# Altium schematic sheet VFD_Clock.SchDoc
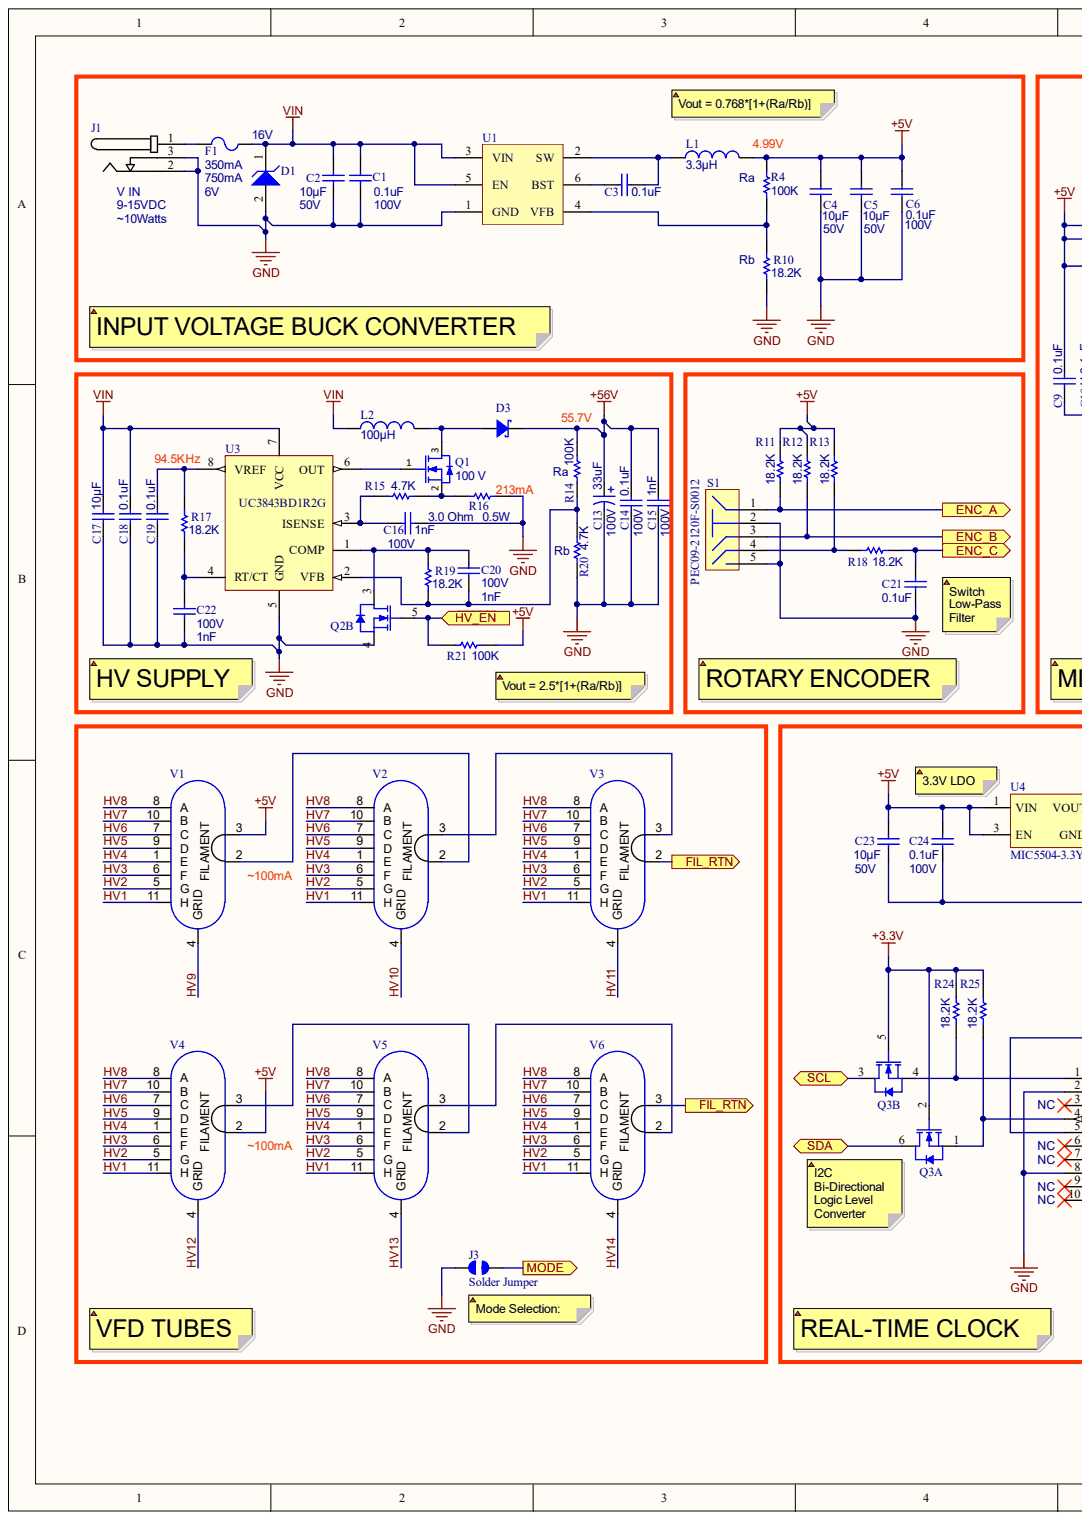
[diagram p0: VFD_Clock.SchDoc, left]
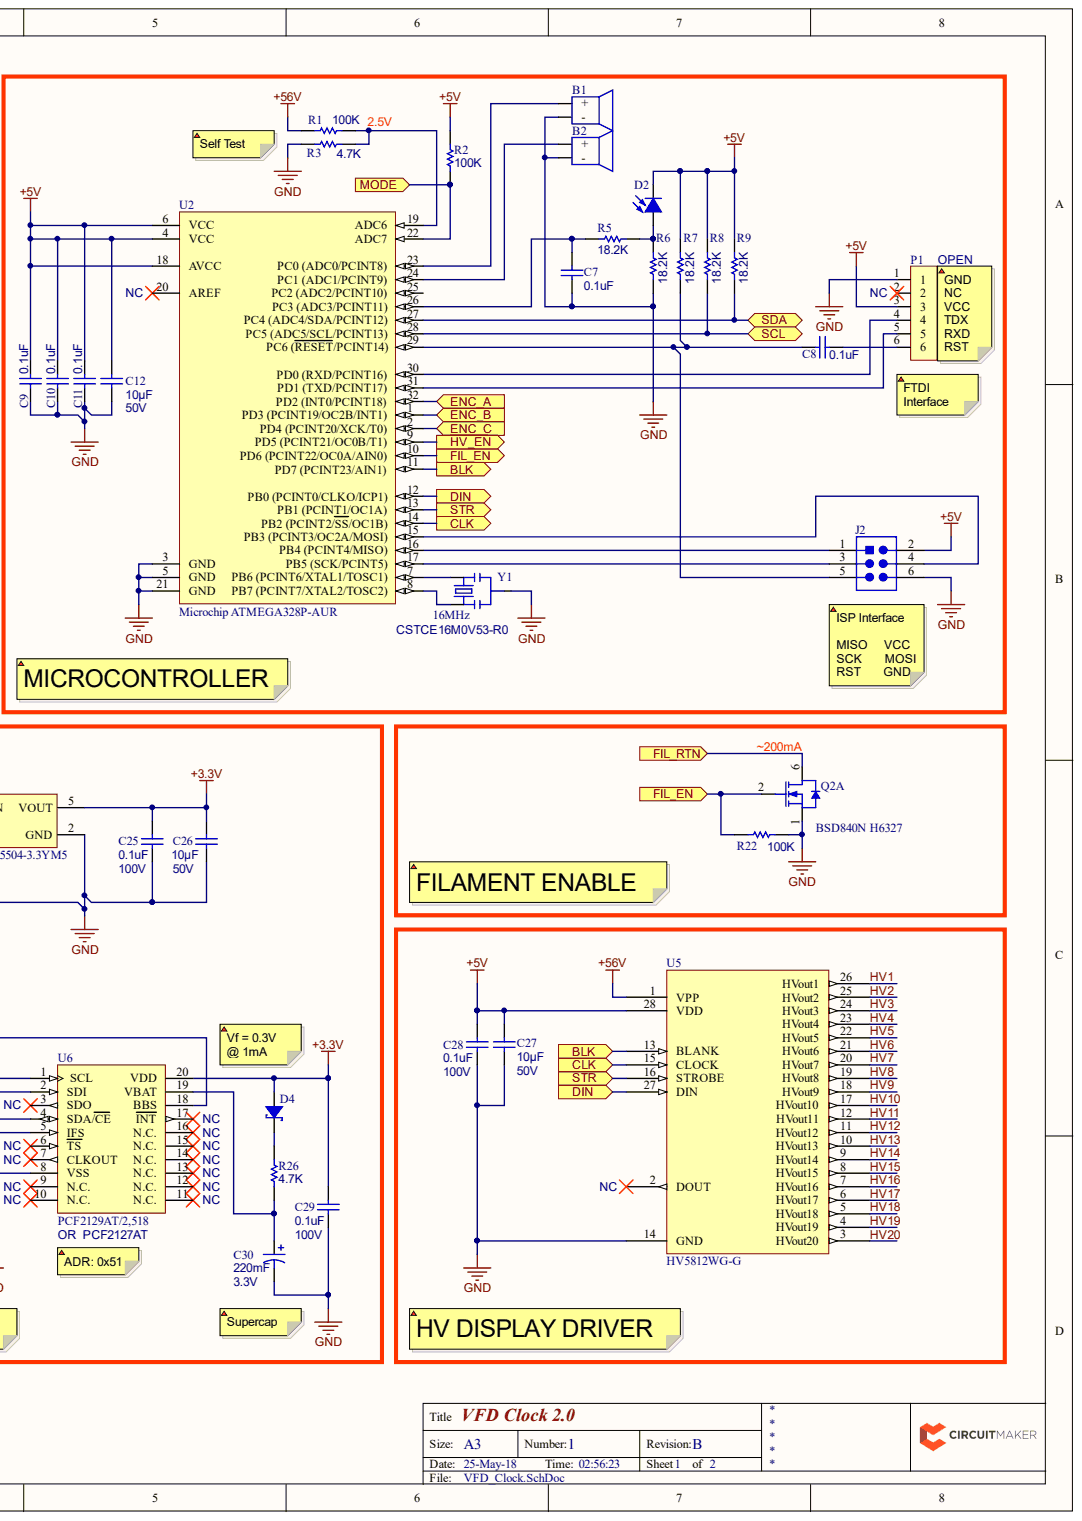
[diagram p1: VFD_Clock.SchDoc, right]

## Parts
B1  PUI Audio AT-1224-TWT-5V-2-R  [BUZZER-12MM-NS]  (value not drawn)
B2  PUI Audio AT-1224-TWT-5V-2-R  [BUZZER-12MM-NS]  (value not drawn)
C1  Capacitance: 0.1uF  Voltage Rating (DC): 100V  [PCB-3l8viaoeo84t9gm70k7i-1]  (hidden comment: Samsung CL21B104KCFNNNE)
C2  Capacitance: 10µF  Voltage Rating (DC): 50V  [PCB-sxvxwfnl72ysl1kmtzgv-1]  (hidden comment: Samsung CL31A106MBHNNNE)
C3  Capacitance: 0.1uF  [PCB-3l8viaoeo84t9gm70k7i-1]  (hidden comment: Samsung CL21B104KCFNNNE)
C4  Capacitance: 10µF  Voltage Rating (DC): 50V  [PCB-sxvxwfnl72ysl1kmtzgv-1]  (hidden comment: Samsung CL31A106MBHNNNE)
C5  Capacitance: 10µF  Voltage Rating (DC): 50V  [PCB-sxvxwfnl72ysl1kmtzgv-1]  (hidden comment: Samsung CL31A106MBHNNNE)
C6  Capacitance: 0.1uF  Voltage Rating (DC): 100V  [PCB-3l8viaoeo84t9gm70k7i-1]  (hidden comment: Samsung CL21B104KCFNNNE)
C7  Capacitance: 0.1uF  [PCB-3l8viaoeo84t9gm70k7i-1]  (hidden comment: Samsung CL21B104KCFNNNE)
C8  Capacitance: 0.1uF  [PCB-3l8viaoeo84t9gm70k7i-1]  (hidden comment: Samsung CL21B104KCFNNNE)
C9  Capacitance: 0.1uF  [PCB-3l8viaoeo84t9gm70k7i-1]  (hidden comment: Samsung CL21B104KCFNNNE)
C10  Capacitance: 0.1uF  [PCB-3l8viaoeo84t9gm70k7i-1]  (hidden comment: Samsung CL21B104KCFNNNE)
C11  Capacitance: 0.1uF  [PCB-3l8viaoeo84t9gm70k7i-1]  (hidden comment: Samsung CL21B104KCFNNNE)
C12  Capacitance: 10µF  Voltage Rating (DC): 50V  [PCB-sxvxwfnl72ysl1kmtzgv-1]  (hidden comment: Samsung CL31A106MBHNNNE)
C13  Capacitance: 33uF  Voltage Rating (DC): 100V  [PCB-u5adhgcz10k2otq2s848-1]  (hidden comment: Nichicon UCD2A330MNL1GS)
C14  Capacitance: 0.1uF  Voltage Rating (DC): 100V  [PCB-3l8viaoeo84t9gm70k7i-1]  (hidden comment: Samsung CL21B104KCFNNNE)
C15  Capacitance: 1nF  Voltage Rating (DC): 100V  [CAPC1608X09M]  (hidden comment: Murata GRM1885C2A102JA01D)
C16  Capacitance: 1nF  Voltage Rating (DC): 100V  [CAPC1608X09M]  (hidden comment: Murata GRM1885C2A102JA01D)
C17  Capacitance: 10µF  [PCB-sxvxwfnl72ysl1kmtzgv-1]  (hidden comment: Samsung CL31A106MBHNNNE)
C18  Capacitance: 0.1uF  [PCB-3l8viaoeo84t9gm70k7i-1]  (hidden comment: Samsung CL21B104KCFNNNE)
C19  Capacitance: 0.1uF  [PCB-3l8viaoeo84t9gm70k7i-1]  (hidden comment: Samsung CL21B104KCFNNNE)
C20  Capacitance: 1nF  Voltage Rating (DC): 100V  [CAPC1608X09M]  (hidden comment: Murata GRM1885C2A102JA01D)
C21  Capacitance: 0.1uF  [PCB-3l8viaoeo84t9gm70k7i-1]  (hidden comment: Samsung CL21B104KCFNNNE)
C22  Capacitance: 1nF  Voltage Rating (DC): 100V  [CAPC1608X09M]  (hidden comment: Murata GRM1885C2A102JA01D)
C23  Capacitance: 10µF  Voltage Rating (DC): 50V  [PCB-sxvxwfnl72ysl1kmtzgv-1]  (hidden comment: Samsung CL31A106MBHNNNE)
C24  Capacitance: 0.1uF  Voltage Rating (DC): 100V  [PCB-3l8viaoeo84t9gm70k7i-1]  (hidden comment: Samsung CL21B104KCFNNNE)
C25  Capacitance: 0.1uF  Voltage Rating (DC): 100V  [PCB-3l8viaoeo84t9gm70k7i-1]  (hidden comment: Samsung CL21B104KCFNNNE)
C26  Capacitance: 10µF  Voltage Rating (DC): 50V  [PCB-sxvxwfnl72ysl1kmtzgv-1]  (hidden comment: Samsung CL31A106MBHNNNE)
C27  Capacitance: 10µF  Voltage Rating (DC): 50V  [PCB-sxvxwfnl72ysl1kmtzgv-1]  (hidden comment: Samsung CL31A106MBHNNNE)
C28  Capacitance: 0.1uF  Voltage Rating (DC): 100V  [PCB-3l8viaoeo84t9gm70k7i-1]  (hidden comment: Samsung CL21B104KCFNNNE)
C29  Capacitance: 0.1uF  Voltage Rating (DC): 100V  [PCB-3l8viaoeo84t9gm70k7i-1]  (hidden comment: Samsung CL21B104KCFNNNE)
C30  Capacitance: 220mF  Voltage Rating (DC): 3.3V  [PCB-ed5g5zejftuwohhu6h14-1]  (hidden comment: Elna DSK-3R3H224U-HL)
D1  Voltage Rating (DC): 16V  [ONSC-SMB-2-403A-03_H_V]  (hidden comment: SMBJ5353B-TP)
D2  Vishay TEPT4400  [PCB-2bwclpntb2i50558vo9u-1]  (value not drawn)
D3  MCC SL110PL-TP  [PCB-zwpadio2r1s9y7nyy7p1-1]  (value not drawn)
D4  MCC SL110PL-TP  [PCB-zwpadio2r1s9y7nyy7p1-1]  (value not drawn)
F1  Current Hold: 350mA  [PCB-4097432-1]  (hidden comment: Bel Fuse 0ZCK0035FF2G)
J1  CUI PJ-102AH  [PCB-nca7odsozp801pu3ngpk-1]  (value not drawn)
J2  Harwin M20-8760342  [TAG-CONNECT]  (value not drawn)
J3  Solder Jumper  [PCB-jf5k9cyfk2c32myyyjc8-1]
L1  Inductance: 3.3µH  [PCB-nsta6in45csbfdu3v3kz-1]  (hidden comment: Bourns SRN6045-3R3Y)
L2  Inductance: 100µH  [PCB-nsta6in45csbfdu3v3kz-1]  (hidden comment: Bourns SRN6045TA-101M)
P1  Würth Elektronik 61300611121  [PCB-inh0upq8uddmt7lk8pts-1]  (value not drawn)
Q1  Drain to Source Voltage (Vds): 100 V  [ONSC-SOT-23-3-318-08_V]  (hidden comment: International Rectifier IRLML0100TRPBF)
Q2  BSD840N H6327  [PCB-o0ftgzu0ah68hvx9yn6p-1]  (parts A,B)
Q3  Infineon BSD840N H6327  [PCB-o0ftgzu0ah68hvx9yn6p-1]  (value not drawn)  (parts A,B)
R1  Resistance: 100K  [PCB-jf5k9cyfk2c32myyyjc8-1]  (hidden comment: Yageo RC0603FR-07100KL)
R2  Resistance: 100K  [PCB-jf5k9cyfk2c32myyyjc8-1]  (hidden comment: Yageo RC0603FR-07100KL)
R3  Resistance: 4.7K  [PCB-jf5k9cyfk2c32myyyjc8-1]  (hidden comment: Yageo RC0603FR-074K7L)
R4  Resistance: 100K  [PCB-jf5k9cyfk2c32myyyjc8-1]  (hidden comment: Yageo RC0603FR-07100KL)
R5  Resistance: 18.2K  [PCB-jf5k9cyfk2c32myyyjc8-1]  (hidden comment: Yageo RC0603FR-0718K2L)
R6  Resistance: 18.2K  [PCB-jf5k9cyfk2c32myyyjc8-1]  (hidden comment: Yageo RC0603FR-0718K2L)
R7  Resistance: 18.2K  [PCB-jf5k9cyfk2c32myyyjc8-1]  (hidden comment: Yageo RC0603FR-0718K2L)
R8  Resistance: 18.2K  [PCB-jf5k9cyfk2c32myyyjc8-1]  (hidden comment: Yageo RC0603FR-0718K2L)
R9  Resistance: 18.2K  [PCB-jf5k9cyfk2c32myyyjc8-1]  (hidden comment: Yageo RC0603FR-0718K2L)
R10  Resistance: 18.2K  [PCB-jf5k9cyfk2c32myyyjc8-1]  (hidden comment: Yageo RC0603FR-0718K2L)
R11  Resistance: 18.2K  [PCB-jf5k9cyfk2c32myyyjc8-1]  (hidden comment: Yageo RC0603FR-0718K2L)
R12  Resistance: 18.2K  [PCB-jf5k9cyfk2c32myyyjc8-1]  (hidden comment: Yageo RC0603FR-0718K2L)
R13  Resistance: 18.2K  [PCB-jf5k9cyfk2c32myyyjc8-1]  (hidden comment: Yageo RC0603FR-0718K2L)
R14  Resistance: 100K  [PCB-jf5k9cyfk2c32myyyjc8-1]  (hidden comment: Yageo RC0603FR-07100KL)
R15  Resistance: 4.7K  [PCB-jf5k9cyfk2c32myyyjc8-1]  (hidden comment: Yageo RC0603FR-074K7L)
R16  Power Rating: 0.5W  [RESC3216X70X50NL20T25]  (hidden comment: RC0603FR-074K7L)
R17  Resistance: 18.2K  [PCB-jf5k9cyfk2c32myyyjc8-1]  (hidden comment: Yageo RC0603FR-0718K2L)
R18  Resistance: 18.2K  [PCB-jf5k9cyfk2c32myyyjc8-1]  (hidden comment: Yageo RC0603FR-0718K2L)
R19  Resistance: 18.2K  [PCB-jf5k9cyfk2c32myyyjc8-1]  (hidden comment: Yageo RC0603FR-0718K2L)
R20  Resistance: 4.7K  [PCB-jf5k9cyfk2c32myyyjc8-1]  (hidden comment: Yageo RC0603FR-074K7L)
R21  Resistance: 100K  [PCB-jf5k9cyfk2c32myyyjc8-1]  (hidden comment: Yageo RC0603FR-07100KL)
R22  Resistance: 100K  [PCB-jf5k9cyfk2c32myyyjc8-1]  (hidden comment: Yageo RC0603FR-07100KL)
R24  Resistance: 18.2K  [PCB-jf5k9cyfk2c32myyyjc8-1]  (hidden comment: Yageo RC0603FR-0718K2L)
R25  Resistance: 18.2K  [PCB-jf5k9cyfk2c32myyyjc8-1]  (hidden comment: Yageo RC0603FR-0718K2L)
R26  Resistance: 4.7K  [PCB-jf5k9cyfk2c32myyyjc8-1]  (hidden comment: Yageo RC0603FR-074K7L)
S1  PEC09-2120F-S0012  [PCB-q6oao8b4bcypfm376829-1]
U1  Texas Instruments TPS561201DDCT  [DBV6_N]  (value not drawn)
U2  Microchip ATMEGA328P-AUR  Manufacturer Part Number: ATMEGA328P-AUR  [PCB-5e0k0uw64gvuqurkqkti-1]
U3  UC3843BD1R2G  [D8_N]
U4  MIC5504-3.3YM5  [PCB-b9c8xxj0e5dnv5apxgdg-1]
U5  HV5812WG-G  [HV5812]
U6  PCF2129AT/2,518  [SO20_N]
V1  IV-12 VFD Tube  [PCB-ore6haii9pr76b042j15-1]  (value not drawn)
V2  IV-12 VFD Tube  [PCB-ore6haii9pr76b042j15-1]  (value not drawn)
V3  IV-12 VFD Tube  [PCB-ore6haii9pr76b042j15-1]  (value not drawn)
V4  IV-12 VFD Tube  [PCB-ore6haii9pr76b042j15-1]  (value not drawn)
V5  IV-12 VFD Tube  [PCB-ore6haii9pr76b042j15-1]  (value not drawn)
V6  IV-12 VFD Tube  [PCB-ore6haii9pr76b042j15-1]  (value not drawn)
Y1  16MHz  Manufacturer Part Number: CSTCE16M0V53-R0  [X3-SMD-3.6X1.3X0.8MM]

## Ports
BLK, CLK, DIN, ENC_A, ENC_B, ENC_C, FIL_EN, FIL_RTN, MODE, SCL, SDA, STR, ~{HV_EN}

## Nets
+3.3V: C25.2 C26.2 C29.2 D4.2 Q3.2 Q3.5 R24.2 R25.2 U4.5(VOUT) U6.20(VDD)
+5V: C4.2 C5.2 C6.1 C9.2 C10.2 C11.2 C12.2 C23.2 C24.2 C27.2 C28.2 D2.2 J2.2 L1.2 P1.3 R2.1 R4.1 R7.2 R8.2 R9.2 R11.2 R12.2 R13.2 R21.1 U2.4(VCC) U2.6(VCC) U2.18(AVCC) U4.1(VIN) U4.3(EN) U5.28(VDD) V1.3 V4.2
+56V: C13.1 C14.2 C15.1 D3.1 R1.2 R14.2 U5.1(VPP)
GND: B1.2 B2.2 C1.1 C2.2 C4.1 C5.1 C6.2 C7.1 C9.1 C10.1 C11.1 C12.1 C13.2 C14.1 C15.2 C16.2 C17.2 C18.1 C19.2 C21.1 C22.2 C23.1 C24.1 C25.1 C26.1 C27.1 C28.1 C29.1 C30.2 D1.2 J1.2 J1.3 J2.6 J3.1 P1.1 Q2.1 Q2.4 R3.2 R6.1 R10.1 R16.2 R20.1 R22.1 S1.2 S1.5 U1.1(GND) U2.3(GND) U2.5(GND) U2.21(GND) U3.5(GND) U4.2(GND) U5.14(GND) U6.2(SDI) U6.8(VSS) Y1.2
VIN: C1.2 C2.1 C17.1 C18.2 D1.1 F1.2 L2.1 U1.3(VIN) U1.5(EN) U3.7(VCC)
~{HV_EN}: Q2.5 R21.2 U2.9(PD5_(PCINT21/OC0B/T1)) ; port ~{HV_EN}
BLK: U2.11(PD7_(PCINT23/AIN1)) U5.13(BLANK) ; port BLK
CLK: U2.14(PB2_(PCINT2/SS/OC1B)) U5.15(CLOCK) ; port CLK
DIN: U2.12(PB0_(PCINT0/CLKO/ICP1)) U5.27(DIN) ; port DIN
ENC_A: R11.1 S1.1 U2.32(PD2_(INT0/PCINT18)) ; port ENC_A
ENC_B: R12.1 S1.3 U2.1(PD3_(PCINT19/OC2B/INT1)) ; port ENC_B
ENC_C: C21.2 R18.1 U2.2(PD4_(PCINT20/XCK/T0)) ; port ENC_C
FIL_EN: Q2.2 R22.2 U2.10(PD6_(PCINT22/OC0A/AIN0)) ; port FIL_EN
FIL_RTN: Q2.6 V3.2 V6.3 ; port FIL_RTN
HV1: U5.26(HVout1) V1.11(H) V2.11(H) V3.11(H) V4.11(H) V5.11(H) V6.11(H)
HV2: U5.25(HVout2) V1.5(G) V2.5(G) V3.5(G) V4.5(G) V5.5(G) V6.5(G)
HV3: U5.24(HVout3) V1.6(F) V2.6(F) V3.6(F) V4.6(F) V5.6(F) V6.6(F)
HV4: U5.23(HVout4) V1.1(E) V2.1(E) V3.1(E) V4.1(E) V5.1(E) V6.1(E)
HV5: U5.22(HVout5) V1.9(D) V2.9(D) V3.9(D) V4.9(D) V5.9(D) V6.9(D)
HV6: U5.21(HVout6) V1.7(C) V2.7(C) V3.7(C) V4.7(C) V5.7(C) V6.7(C)
HV7: U5.20(HVout7) V1.10(B) V2.10(B) V3.10(B) V4.10(B) V5.10(B) V6.10(B)
HV8: U5.19(HVout8) V1.8(A) V2.8(A) V3.8(A) V4.8(A) V5.8(A) V6.8(A)
HV9: U5.18(HVout9) V1.4(GRID)
HV10: U5.17(HVout10) V2.4(GRID)
HV11: U5.12(HVout11) V3.4(GRID)
HV12: U5.11(HVout12) V4.4(GRID)
HV13: U5.10(HVout13) V5.4(GRID)
HV14: U5.9(HVout14) V6.4(GRID)
HV15: U5.8(HVout15)
HV16: U5.7(HVout16)
HV17: U5.6(HVout17)
HV18: U5.5(HVout18)
HV19: U5.4(HVout19)
HV20: U5.3(HVout20)
MODE: J3.2 R2.2 U2.22(ADC7) ; port MODE
SCL: Q3.3 R8.1 U2.28(PC5_(ADC5/SCL/PCINT13)) ; port SCL
SDA: Q3.6 R9.1 U2.27(PC4_(ADC4/SDA/PCINT12)) ; port SDA
STR: U2.13(PB1_(PCINT1/OC1A)) U5.16(STROBE) ; port STR
NetB1_1: B1.1 U2.23(PC0_(ADC0/PCINT8))
NetB2_1: B2.1 U2.24(PC1_(ADC1/PCINT9))
NetC3_1: C3.1 L1.1 U1.2(SW)
NetC3_2: C3.2 U1.6(BST)
NetC7_2: C7.2 R5.2 U2.26(PC3_(ADC3/PCINT11))
NetC8_1: C8.1 P1.6
NetC8_2: C8.2 J2.5 R7.1 U2.29(PC6_(RESET/PCINT14))
NetC16_1: C16.1 R15.2 U3.3(ISENSE)
NetC19_1: C19.1 R17.2 U3.8(VREF)
NetC20_1: C20.1 R14.1 R19.1 R20.2 U3.2(VFB)
NetC20_2: C20.2 Q2.3 R19.2 U3.1(COMP)
NetC22_1: C22.1 R17.1 U3.4(RT/CT)
NetC30_1: C30.1 R26.1 U6.19(VBAT)
NetD2_1: D2.1 R5.1 R6.2
NetD3_2: D3.2 L2.2 Q1.3
NetD4_1: D4.1 R26.2
NetF1_1: F1.1 J1.1
NetJ2_1: J2.1 U2.16(PB4_(PCINT4/MISO))
NetJ2_3: J2.3 U2.17(PB5_(SCK/PCINT5))
NetJ2_4: J2.4 U2.15(PB3_(PCINT3/OC2A/MOSI))
NetP1_4: P1.4 U2.30(PD0_(RXD/PCINT16))
NetP1_5: P1.5 U2.31(PD1_(TXD/PCINT17))
NetQ1_1: Q1.1 U3.6(OUT)
NetQ1_2: Q1.2 R15.1 R16.1
NetQ3_1: Q3.1 R25.1 U6.4(SDA/CE)
NetQ3_4: Q3.4 R24.1 U6.1(SCL)
NetR1_1: R1.1 R3.1 U2.19(ADC6)
NetR4_2: R4.2 R10.2 U1.4(VFB)
NetR13_1: R13.1 R18.2 S1.4
NetU2_7: U2.7 Y1.3
NetU2_8: U2.8 Y1.1
NetU6_5: U6.5(IFS) U6.18(BBS)
NetV1_2: V1.2 V2.2
NetV2_3: V2.3 V3.3
NetV4_3: V4.3 V5.2
NetV5_3: V5.3 V6.2

Not connected: P1 2; U2 20(AREF) 25; U5 2(DOUT); U6 3(SDO) 6(TS) 7(CLKOUT) 9(N.C.) 10(N.C.) 11(N.C.) 12(N.C.) 13(N.C.) 14(N.C.) 15(N.C.) 16(N.C.) 17(INT)

## Library data of the parts
B1 B2 = CMP-e11e2b16fc6f3ed9-1: Audio Indicator Transducer Electromagnetic 5Vp-p 40mA Pin 3Vp-p 1900Hz to 2900Hz Through Hole; Current Rating: 40.0 mA; Resonant Frequency: 2.40 kHz; Component Kind: Standard; Library Name: CommunityVault; Manufacturer Part Number: AT-1224-TWT-5V-2-R; Mounting Style: Through Hole; OctopartID: e11e2b16fc6f3ed9; Octopart URL: http://octopart.com/at-1224-twt-5v-2-r-pui+audio-50477079; Packaging: Tray
C1 C3 C6-C11 C14 C18 C19 C21 C24 C25 C28 C29 = CMP-a5716d0754de7ab6-2: CL21 Series 0.1 uF 100V ±10 % Tolerance X7R SMT Multilayer Ceramic Capacitor; Capacitance Tolerance: ±10%; Lead-Free Status: Lead Free; Case/Package: 0805; Component Kind: Standard; Dielectric Characteristic: X7R; Library Name: CommunityVault; Manufacturer Part Number: CL21B104KCFNNNE; Mounting Style: Surface Mount; OctopartID: a5716d0754de7ab6; Octopart URL: http://octopart.com/cl21b104kcfnnne-samsung-24958153; Packaging: Tape & Reel (TR); RoHS: Compliant
  C3 C7-C11 C18 C19 C21: Voltage Rating (DC): 100V
C2 C4 C5 C12 C17 C23 C26 C27 = CMP-32a5ffa1fad6ed62-3: Cap Ceramic 10uF 50V X5R 20% SMD 1206 85°C Embossed T/R; Capacitance Tolerance: ±20%; Case/Package: 1206; Component Kind: Standard; Dielectric Characteristic: X5R; Library Name: CommunityVault; Manufacturer Part Number: CL31A106MBHNNNE; OctopartID: 32a5ffa1fad6ed62; Octopart URL: https://octopart.com/cl31a106mbhnnne-samsung-68087061
  C17: Voltage Rating (DC): 50V
C13 = CMP-25b5ed93ddd2fa72-1: Cap Aluminum Lytic 33uF 100V 20% (10 X 10mm) SMD 200mA 2000h 105 Automotive T/R; Lead-Free Status: Lead Free; Capacitance Tolerance: ±20%; Component Kind: Standard; Library Name: CommunityVault; Manufacturer Part Number: UCD2A330MNL1GS; Mounting Style: Surface Mount; OctopartID: 25b5ed93ddd2fa72; Octopart URL: http://octopart.com/ucd2a330mnl1gs-nichicon-19717377; Packaging: Tape & Reel (TR); RoHS: Compliant; Size-Diameter: 10.0 mm; Size-Height: 10.0 mm
C15 = CMP-119701-4: Ceramic capacitor SMD 0603 1 nF 100 V 5 % Murata GRM1885C2A102JA01D 4000 pc(s); Capacitance Tolerance: ±5%; Case/Package (SI): 1608; Dielectric Characteristic: C0G/NP0; Lead-Free Status: Lead Free; Case/Package: 0603, 1608; Component Kind: Standard; Library Name: CommunityVault; Lifecycle Status: Active; Manufacturer Part Number: GRM1885C2A102JA01D; Mounting Style: Surface Mount; OctopartID: c2040b0de6461dc3; Octopart URL: http://octopart.com/grm1885c2a102ja01d-murata-196900; Packaging: Cut Tape (CT), Tape & Reel (TR); RoHS: Compliant; Size-Height: 800 µm; Size-Length: 1.60 mm; Size-Thickness: 800 µm; Size-Width: 800 µm
C16 C20 C22 = CMP-119701-4: Ceramic capacitor SMD 0603 1 nF 100 V 5 % Murata GRM1885C2A102JA01D 4000 pc(s); Capacitance Tolerance: ±5%; Case/Package (SI): 1608; Dielectric Characteristic: C0G/NP0; Lead-Free Status: Lead Free; Side: Top; Case/Package: 0603, 1608; Component Kind: Standard; Library Name: CommunityVault; Lifecycle Status: Active; Manufacturer Part Number: GRM1885C2A102JA01D; Mounting Style: Surface Mount; OctopartID: c2040b0de6461dc3; Octopart URL: http://octopart.com/grm1885c2a102ja01d-murata-196900; Packaging: Cut Tape (CT), Tape & Reel (TR); RoHS: Compliant; Size-Height: 800 µm; Size-Length: 1.60 mm; Size-Thickness: 800 µm; Size-Width: 800 µm
C30 = CMP-afc466cd99917003-3: DSK Series 0.22F 3.3 V ±30% Coin Cell Aluminum Electrolytic Dynacap Capacitor; Lead-Free Status: Lead Free; Capacitance Tolerance: ±30%; Component Kind: Standard; Library Name: CommunityVault; Manufacturer Part Number: DSK-3R3H224U-HL; Mounting Style: Surface Mount; OctopartID: afc466cd99917003; Octopart URL: https://octopart.com/dsk-3r3h224u-hl-elna-201523; Packaging: Reel; RoHS: Compliant; Size-Diameter: 6.80 mm; Size-Height: 2.80 mm
D1 = CMP-6803481-2: 5W Zener Voltage Regulator, 2-Pin SMB, Pb-Free, Tape and Reel; Lead-Free Status: Lead Free; Material: Plastic; Power Rating: 5.0 W; Case/Package: SMB; Component Kind: Standard; Halogen Free Status: Halogen Free; Library Name: CommunityVault; Lifecycle Status: Active; Manufacturer Part Number: SMBJ5353B-TP; Mounting Style: Surface Mount; Number of Pins: 2; OctopartID: 94595d5741254b9e; Octopart Url: https://octopart.com/smbj5353b-tp-mcc-19246165; REACH SVHC Compliance: No SVHC; RoHS: Compliant
D2 = CMP-db9d10175b66045a-3: NPN 570 nm 5 V 200 uA Through Hole Analog Ambient Light Sensor - T-1; Breakdown Voltage [Collector to Emitter]: 6.00 V; Lead-Free Status: Lead Free; Peak Wavelength: 570 nm; Power Consumption: 100 mW; Side: Top; Viewing Angle: 30 °; Component Kind: Standard; Library Name: CommunityVault; Manufacturer Part Number: TEPT4400; Mounting Style: Through Hole; Number of Pins: 2; OctopartID: db9d10175b66045a; Octopart URL: http://octopart.com/tept4400-vishay-41985437; Packaging: Reel; Polarity: NPN; RoHS: Compliant; Wavelength: 570 nm
D3 D4 = CMP-f0c43dba931b2f03-2: Diode Schottky 100v 1a Sod123fl; Side: Top; Component Kind: Standard; Library Name: CommunityVault; Manufacturer Part Number: SL110PL-TP; OctopartID: f0c43dba931b2f03; Octopart URL: http://octopart.com/sl110pl-tp-mcc-74729145
F1 = CMP-b03278f8383a6ff5-1: Bel, Internal Part #: 0zck0035ff2g; Current Trip: 750mA; Voltage: 6V; Case/Package: 0805; Component Kind: Standard; Library Name: CommunityVault; Manufacturer Part Number: 0ZCK0035FF2G; OctopartID: b03278f8383a6ff5; Octopart URL: http://octopart.com/0zck0035ff2g-bel+fuse-29404526; Packaging: Tape & Reel (TR); RoHS: Compliant
J1 = CMP-c75a0e5482e5189d-7: 2.0 mm Center Pin, 5.0 A, Right Angle, Through Hole, Tapered Pins, Dc Power Jack Connector; Gender: Male; Lead-Free Status: Lead Free; Mounting Angle: 90.0 °; Shielding: No; Color: Black; Component Kind: Standard; Contact Plating: Nickel; Library Name: CommunityVault; Lifecycle Status: Active; Manufacturer Part Number: PJ-102AH; Mounting Style: Through Hole; OctopartID: c75a0e5482e5189d; Octopart URL: http://octopart.com/pj-102ah-cui-286937; Orientation: Horizontal; Packaging: Bulk; RoHS: Compliant; Size-Diameter: 2.10 mm
J2 = CMP-6cc2a5c3a8e4b305-3: TAG-CONNECT; Component Kind: Standard; Library Name: CommunityVault
J3 = CMP-429d8b974e079d5a-10: Component Kind: Standard; Description: Solder Jumper; Library Name: CommunityVault; OctopartID: 429d8b974e079d5a
L1 = CMP-82d64ae6a43d5d11-2: Inductor Power Semi-Shielded Wirewound 3.3uH 30% 100KHz 15Q-Factor Ferrite 3.2A 30.2mOhm DCR T/R; Component Kind: Standard; Library Name: CommunityVault; Manufacturer Part Number: SRN6045-3R3Y; Mounting Style: Surface Mount; Number of Pins: 2; OctopartID: 82d64ae6a43d5d11; Octopart URL: http://octopart.com/srn6045-3r3y-bourns-20579213; Packaging: Cut Tape (CT); RoHS: Compliant
L2 = CMP-d2051f74cedd0dc2-1: Inductor Power Semi-Shielded Wirewound 100uH 20% 1MHz 15Q-Factor Ferrite 920mA 456mOhm DCR 2424 Automotive T/R; Component Kind: Standard; Library Name: CommunityVault; Manufacturer Part Number: SRN6045TA-101M; OctopartID: d2051f74cedd0dc2; Octopart URL: http://octopart.com/srn6045ta-101m-bourns-75184574; Packaging: Cut Tape (CT); RoHS: Compliant
P1 = CMP-5a24914ca12285e3-2: Board-To-Board Connector, Vertical, WR-PHD Series, Through Hole, Header, 6, 2.54 mm; Gender: Male; Lead-Free Status: Lead Free; Number of Contacts: 6; Pin Pitch: 2.54 mm; Color: Black; Component Kind: Standard; Contact Material: Phosphor Bronze; Contact Plating: Gold; Library Name: CommunityVault; Lifecycle Status: Not Listed by Manufacturer; Manufacturer Part Number: 61300611121; Mounting Style: Through Hole, Vertical; Number of Positions: 6; Number of Rows: 1; OctopartID: 3b8ff3b76987e448; Octopart URL: http://octopart.com/61300611121-w%C3%BCrth+elektronik-20084590; REACH SVHC Compliance: No SVHC; RoHS: Compliant
Q1 = CMP-b7077ecd418068c0-5: Single N-Channel 100 V 1.3 W 2.5 nC Hexfet Power Mosfet Surface Mount - MICRO-3; Continuous Drain Current (Ids): 1.60 A; Lead-Free Status: Lead Free; Power Dissipation: 1.30 W; Component Kind: Standard; Library Name: CommunityVault; Lifecycle Status: Active; Manufacturer Part Number: IRLML0100TRPBF; Mounting Style: Surface Mount; Number of Pins: 3; OctopartID: b7077ecd418068c0; Octopart URL: http://octopart.com/irlml0100trpbf-international+rectifier-12637153; Packaging: Cut Tape (CT); Part Family: IRLML0100; Polarity: N-Channel; REACH SVHC Compliance: No SVHC; RoHS: Compliant
Q2 = CMP-0e74c63de663d1b3-2: Dual N-Channel 20 V 400 mO 0.26 nC OptiMOS 2 Small Signal Transistor - SOT-363; Manufacturer Part Number: BSD840NH6327XTSA1; Lead-Free Status: Lead Free; Case/Package: SOT-363-6; Component Kind: Standard; Library Name: CommunityVault; Mounting Style: Surface Mount; Number of Pins: 6; OctopartID: 0e74c63de663d1b3; Octopart URL: http://octopart.com/bsd840n+h6327-infineon-21220721; Polarity: Dual N-Channel; REACH SVHC Compliance: No SVHC; RoHS: Compliant
Q3 = CMP-0e74c63de663d1b3-2: Dual N-Channel 20 V 400 mO 0.26 nC OptiMOS 2 Small Signal Transistor - SOT-363; Manufacturer Part Number: BSD840NH6327XTSA1; Lead-Free Status: Lead Free; Side: Top; Case/Package: SOT-363-6; Component Kind: Standard; Library Name: CommunityVault; Mounting Style: Surface Mount; Number of Pins: 6; OctopartID: 0e74c63de663d1b3; Octopart URL: http://octopart.com/bsd840n+h6327-infineon-21220721; Polarity: Dual N-Channel; REACH SVHC Compliance: No SVHC; RoHS: Compliant
R1 R2 R4 R21 R22 = CMP-9abf51c576ad4578-10: RC Series 0603 0.1 W 100 kOhms 1% ±100 ppm/°C SMT Thick Film Chip Resistor; Case/Package (SI): 1608; Lead-Free Status: Lead Free; Power Rating: 100 mW; Voltage Rating (DC): 50.0 V; Case/Package: 0603; Component Kind: Standard; Library Name: CommunityVault; Manufacturer Part Number: RC0603FR-07100KL; Mounting Style: Surface Mount; Number of Pins: 2; OctopartID: 9abf51c576ad4578; Octopart URL: http://octopart.com/rc0603fr-07100kl-yageo-40946036; Packaging: Cut Tape (CT), Tape & Reel (TR); REACH SVHC Compliance: No SVHC; Resistance Tolerance: ±1%; RoHS: Compliant; Size-Height: 450 µm; Size-Length: 1.60 mm; Size-Width: 800 µm
R3 R15 R20 R26 = CMP-e2bf28f8a65d3d78-7: Res Thick Film 1.6 x 0.8 mm 4.7K Ohm 1% 0.1W(1/10W) 100ppm/ C Molded SMD Paper T/R; Case/Package (SI): 1608; Lead-Free Status: Lead Free; Power Rating: 100 mW; Voltage Rating (DC): 50.0 V; Case/Package: 0603; Component Kind: Standard; Library Name: CommunityVault; Manufacturer Part Number: RC0603FR-074K7L; Mounting Style: Surface Mount; Number of Pins: 2; OctopartID: e2bf28f8a65d3d78; Octopart URL: http://octopart.com/rc0603fr-074k7l-yageo-55402884; Packaging: Tape & Reel; REACH SVHC Compliance: No SVHC; Resistance Tolerance: ±1%; RoHS: Compliant; Size-Height: 450 µm; Size-Length: 1.60 mm; Size-Width: 800 µm
R5-R13 R18 = CMP-161edd6d611ac9d9-2: Res Thick Film 1.6 x 0.8 mm 18.2K Ohm 1% 0.1W(1/10W) 100ppm/ C Molded SMD Paper T/R; Case/Package (SI): 1608; Lead-Free Status: Lead Free; Power Rating: 100 mW; Voltage Rating (DC): 50.0 V; Case/Package: 0603; Component Kind: Standard; Library Name: CommunityVault; Manufacturer Part Number: RC0603FR-0718K2L; Mounting Style: Surface Mount; OctopartID: 161edd6d611ac9d9; Octopart URL: http://octopart.com/rc0603fr-0718k2l-yageo-41505378; Packaging: Reel; Resistance Tolerance: ±1%; RoHS: Compliant; Size-Height: 450 µm; Size-Length: 1.60 mm; Size-Width: 800 µm
R14 = CMP-9abf51c576ad4578-10: RC Series 0603 0.1 W 100 kOhms 1% ±100 ppm/°C SMT Thick Film Chip Resistor; Case/Package (SI): 1608; Lead-Free Status: Lead Free; Power Rating: 100 mW; Side: Top; Voltage Rating (DC): 50.0 V; Case/Package: 0603; Component Kind: Standard; Library Name: CommunityVault; Manufacturer Part Number: RC0603FR-07100KL; Mounting Style: Surface Mount; Number of Pins: 2; OctopartID: 9abf51c576ad4578; Octopart URL: http://octopart.com/rc0603fr-07100kl-yageo-40946036; Packaging: Cut Tape (CT), Tape & Reel (TR); REACH SVHC Compliance: No SVHC; Resistance Tolerance: ±1%; RoHS: Compliant; Size-Height: 450 µm; Size-Length: 1.60 mm; Size-Width: 800 µm
R16 = CMP-2641ee67095af986-1: Res Thick Film 1206 1 Ohm 5% 1/4W ±200ppm/°C Molded SMD SMD T/R; Manufacturer Part Number: CRM1206-JW-1R0ELF; Case/Package (SI): 3216; Resistance: 3.0 Ohm; Resistance Tolerance: ±1%; Voltage Rating (DC): 200 V; Case/Package: 1206, 3216; Component Kind: Standard; Library Name: CommunityVault; Mounting Style: Surface Mount; Number of Pins: 2; OctopartID: 2641ee67095af986; Octopart URL: http://octopart.com/crm1206-jw-1r0elf-bourns-19663485; Packaging: Tape & Reel (TR), Cut Tape (CT); REACH SVHC Compliance: No SVHC; RoHS: Compliant
R17 R19 R24 R25 = CMP-161edd6d611ac9d9-2: Res Thick Film 1.6 x 0.8 mm 18.2K Ohm 1% 0.1W(1/10W) 100ppm/ C Molded SMD Paper T/R; Case/Package (SI): 1608; Lead-Free Status: Lead Free; Power Rating: 100 mW; Side: Top; Voltage Rating (DC): 50.0 V; Case/Package: 0603; Component Kind: Standard; Library Name: CommunityVault; Manufacturer Part Number: RC0603FR-0718K2L; Mounting Style: Surface Mount; OctopartID: 161edd6d611ac9d9; Octopart URL: http://octopart.com/rc0603fr-0718k2l-yageo-41505378; Packaging: Reel; Resistance Tolerance: ±1%; RoHS: Compliant; Size-Height: 450 µm; Size-Length: 1.60 mm; Size-Width: 800 µm
S1 = CMP-1fcfd7e18604c008-2: Encoder Incremental w/Switch 9mm; Number of Detents: 12; Component Kind: Standard; Library Name: CommunityVault; Manufacturer Part Number: PEC09-2120F-S0012; Mounting Style: Through Hole, Panel, PCB, PC Board; OctopartID: 1fcfd7e18604c008; Octopart URL: http://octopart.com/pec09-2120f-s0012-bourns-19184813; RoHS: Compliant; Size-Height: 11.4 mm; Size-Length: 33.3 mm; Size-Width: 9.70 mm
U1 = CMP-60eaad0142debf2d-1: Conv DC-DC 4.5V to 17V Synchronous Step Down Single-Out 0.76V to 7V 1A 6-Pin TSOT-23 T/R; Lead-Free Status: Lead Free; Number of Regulated Outputs: 1; Output Current: 1.00 A; Quiescent Current: 400 µA; Topology: Buck; Component Kind: Standard; Library Name: CommunityVault; Lifecycle Status: Active; Manufacturer Part Number: TPS561201DDCT; OctopartID: 60eaad0142debf2d; Octopart URL: http://octopart.com/tps561201ddct-texas+instruments-81856338; Packaging: Cut Tape (CT); RoHS: Compliant
U2 = CMP-2e4ee4a00354f189-5: AVR 32KBytes Flash, 1KBytes EEPROM, 2KBytes RAM, w - 20MHZ, TQFP, IND TEMP, GREEN 5 V T&R/ TQFP (A); Case/Package: 32-TQFP; Component Kind: Standard; Library Name: CommunityVault; Lifecycle Status: Not Listed by Manufacturer; OctopartID: 9e7d55d3fe1041ba; Octopart URL: https://octopart.com/atmega328p-aur-microchip-77760216; Packaging: Tape & Reel (TR)
U3 = CMP-bacf73c2076d6508-3: UC3843B Series 30 V 275 kHz High Performance Current Mode Controller - SOIC-8; Frequency: 52.0 kHz; Input Voltage (DC): 30.0 V; Lead-Free Status: Lead Free; Number of Outputs: 1; Side: Top; Supply Voltage (DC): 30.0 V (max); Topology: Flyback; Case/Package: 8-SOIC; Component Kind: Standard; Halogen Free Status: Halogen Free; Library Name: CommunityVault; Lifecycle Status: Active; Manufacturer Part Number: UC3843BD1R2G; Mounting Style: Surface Mount; Number of Pins: 8; OctopartID: bacf73c2076d6508; Octopart URL: http://octopart.com/uc3843bd1r2g-on+semiconductor-497860; Packaging: Cut Tape (CT); RoHS: Compliant
U4 = CMP-14bfde4e6706cb78-3: MIC5504 Series 3.3 V 300 A Surface Mount Advanced General Purpose LDO-SOT-23-5; Manufacturer Part Number: MIC5504-3.3YM5-TR; Lead-Free Status: Lead Free; Output Current: 300 mA; Side: Top; Case/Package: SOT-23-5; Component Kind: Standard; Library Name: CommunityVault; Lifecycle Status: Not Listed by Manufacturer; Number of Pins: 5; OctopartID: 14bfde4e6706cb78; Octopart URL: http://octopart.com/mic5504-3.3ym5-tr-microchip-66803423; Packaging: Cut Tape (CT); REACH SVHC Compliance: No SVHC; RoHS: Compliant
U5 = CMP-6d4ca689c931b28b-3: 20-CHANNEL, DISPLAY DRIVER, 80V, 28 SOIC .300in T/R; Side: Top; Supply Current: 300 mA; Case/Package: 28-SOIC; Component Kind: Standard; Library Name: CommunityVault; Lifecycle Status: Not Listed by Manufacturer; Manufacturer Part Number: HV5812WG-G; OctopartID: 6d4ca689c931b28b; Octopart URL: http://octopart.com/hv5812wg-g-microchip-47153435; RoHS: Compliant
U6 = CMP-7b28b3573967a4ef-2: Timer IC t- real time clock NXP Semiconductors PCF2129AT/2, 518 Clock/calendar SO 20; Lead-Free Status: Lead Free; Side: Top; Supply Voltage (DC): 1.80 V (min); Case/Package: 20-SOIC; Component Kind: Standard; Library Name: CommunityVault; Lifecycle Status: Active; Manufacturer Part Number: PCF2129AT/2,518; Mounting Style: Surface Mount; Number of Pins: 20; OctopartID: 7b28b3573967a4ef; Octopart URL: http://octopart.com/pcf2129at%2F2%2C518-nxp+semiconductors-24471337; Packaging: Cut Tape (CT); REACH SVHC Compliance: No SVHC; RoHS: Compliant
V1-V6 = CMP-pqj90w66plrkudjornca-9: IV-12 Vacuum Fluorescent Display Tube; Side: Top; Component Kind: Standard; Library Name: CommunityVault
Y1 = CMP-798854-8: Ceramic Resonator 16MHz ??0.5% (Tol) ??0.3% (Stability) 15pF 40Ohm 3-Pin CSMD T/R; Frequency: 16000000.0 Hz; Lead-Free Status: Lead Free; Load Capacitance: 1.5e-11 F; Component Kind: Standard; Digikey: 490-1198-1-ND; Library Name: CommunityVault; Mounting Style: Surface Mount; Number of Pins: 3; OctopartID: 122fdb21fb4dcde8; Octopart Url: http://octopart.com/cstce16m0v53-r0-murata-314372; REACH SVHC Compliance: No SVHC; RoHS: Compliant; Seller Landing  Url: https://octopart-clicks.com/click/track?country=US&ak=8157f166&sig=04310e5&sid=5489&ppid=314372&vpid=22487019&ct=offers; Size-Height: 0.0009 m; Size-Length: 0.0032 m; Size-Width: 0.0013 m
